# Altium schematic sheet AudioM_PowerAmp_v1.0.SchDoc
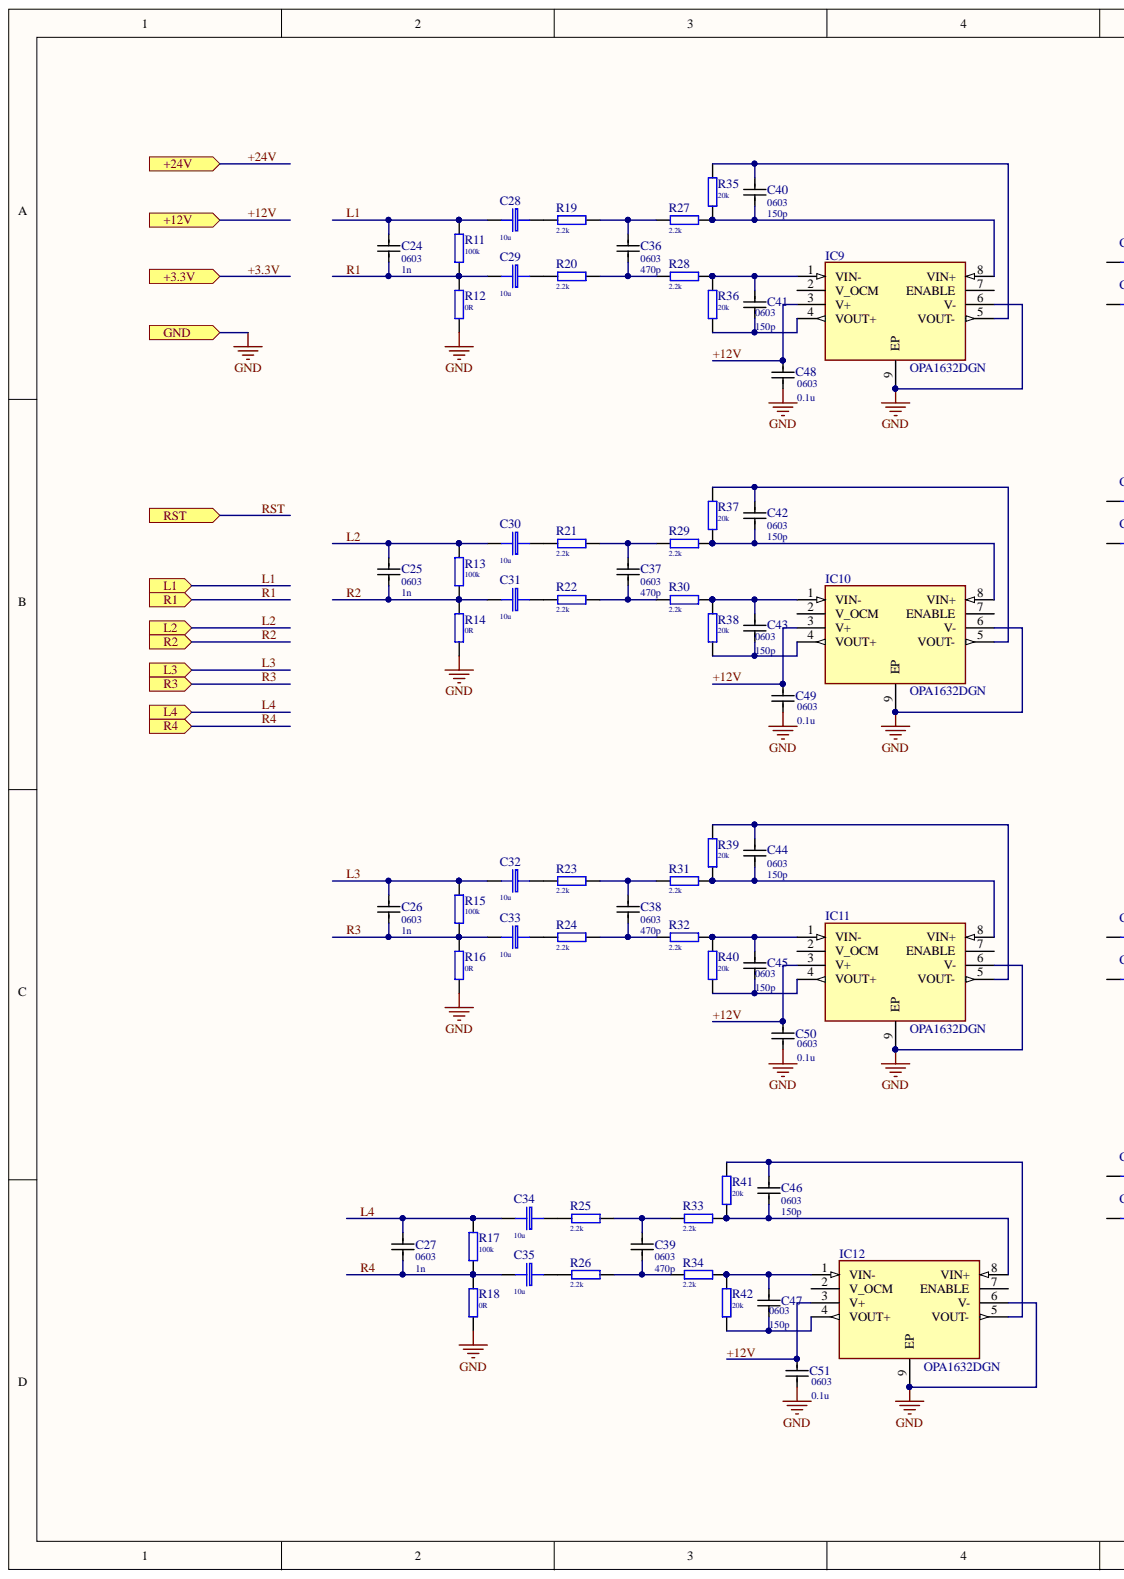
[diagram p0: AudioM_PowerAmp_v1.0.SchDoc, left]
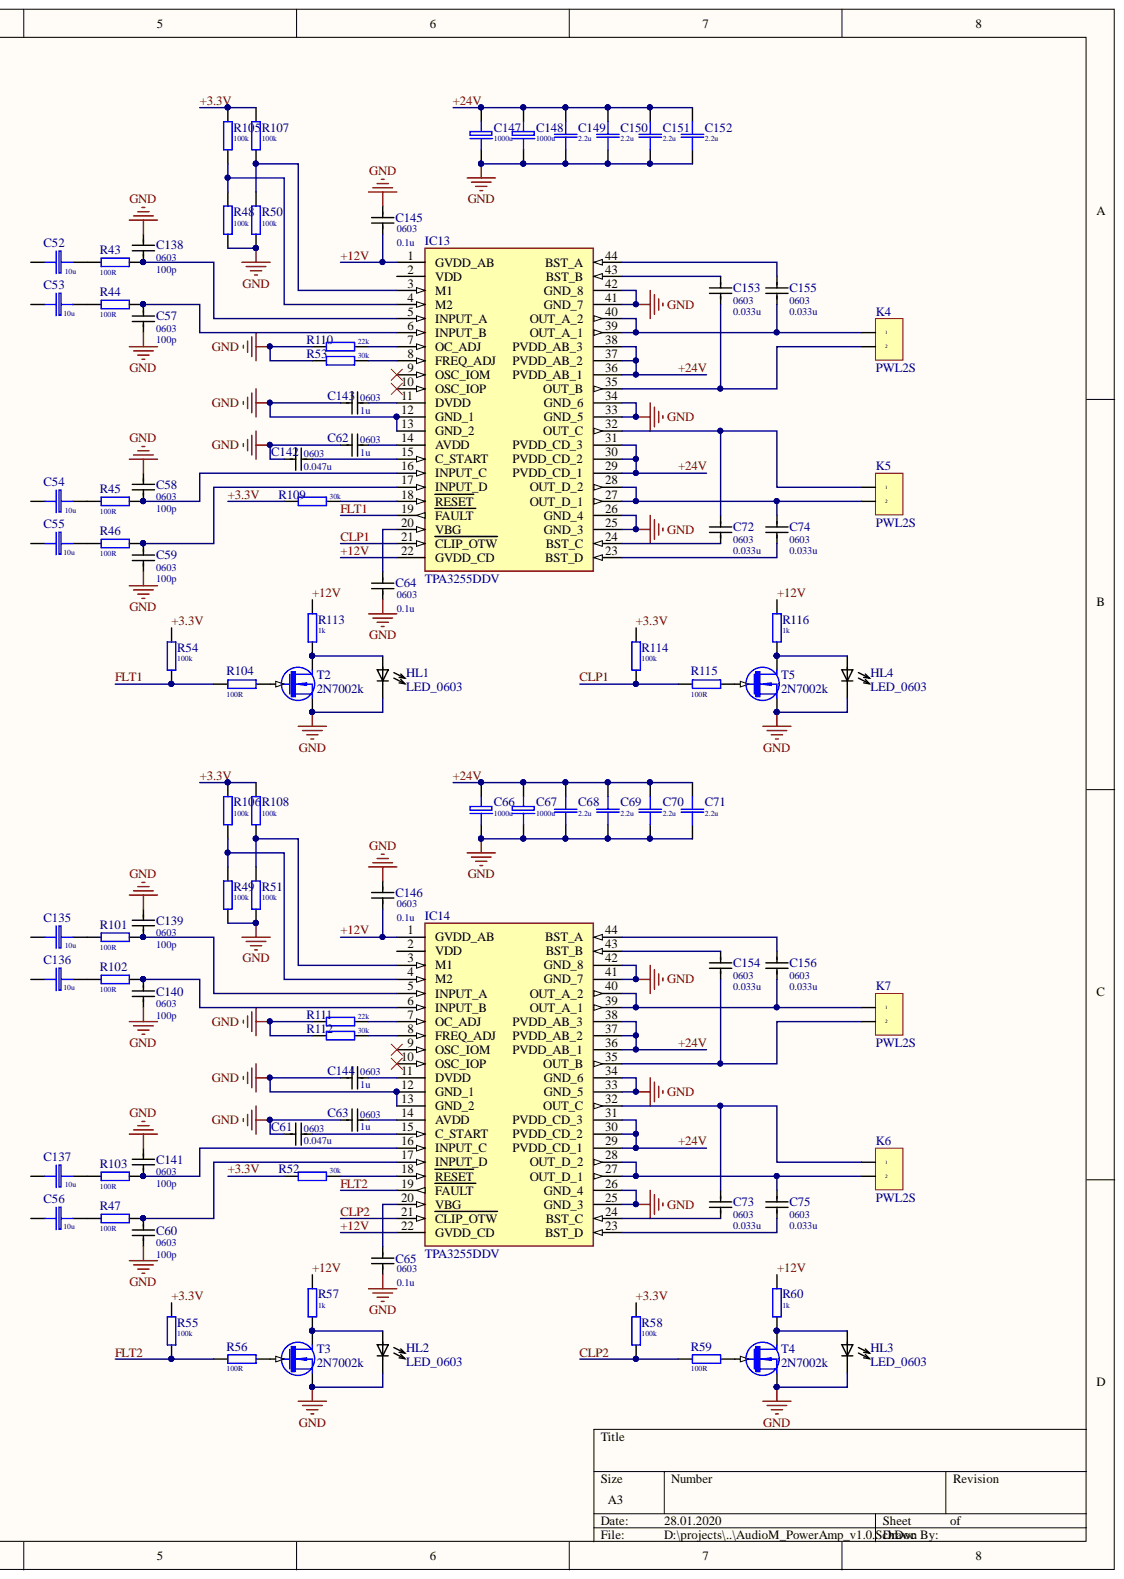
[diagram p1: AudioM_PowerAmp_v1.0.SchDoc, right]

## Parts
C24  0603  value 1n  [CAPC1608N]
C25  0603  value 1n  [CAPC1608N]
C26  0603  value 1n  [CAPC1608N]
C27  0603  value 1n  [CAPC1608N]
C28  10u  [EL Case B (3528-21)]
C29  10u  [EL Case B (3528-21)]
C30  10u  [EL Case B (3528-21)]
C31  10u  [EL Case B (3528-21)]
C32  10u  [EL Case B (3528-21)]
C33  10u  [EL Case B (3528-21)]
C34  10u  [EL Case B (3528-21)]
C35  10u  [EL Case B (3528-21)]
C36  0603  value 470p  [CAPC1608N]
C37  0603  value 470p  [CAPC1608N]
C38  0603  value 470p  [CAPC1608N]
C39  0603  value 470p  [CAPC1608N]
C40  0603  value 150p  [CAPC1608N]
C41  0603  value 150p  [CAPC1608N]
C42  0603  value 150p  [CAPC1608N]
C43  0603  value 150p  [CAPC1608N]
C44  0603  value 150p  [CAPC1608N]
C45  0603  value 150p  [CAPC1608N]
C46  0603  value 150p  [CAPC1608N]
C47  0603  value 150p  [CAPC1608N]
C48  0603  value 0.1u  [CAPC1608N]
C49  0603  value 0.1u  [CAPC1608N]
C50  0603  value 0.1u  [CAPC1608N]
C51  0603  value 0.1u  [CAPC1608N]
C52  10u  [EL Case B (3528-21)]
C53  10u  [EL Case B (3528-21)]
C54  10u  [EL Case B (3528-21)]
C55  10u  [EL Case B (3528-21)]
C56  10u  [EL Case B (3528-21)]
C57  0603  value 100p  [CAPC1608N]
C58  0603  value 100p  [CAPC1608N]
C59  0603  value 100p  [CAPC1608N]
C60  0603  value 100p  [CAPC1608N]
C61  0603  value 0.047u  [CAPC1608N]
C62  0603  value 1u  [CAPC1608N]
C63  0603  value 1u  [CAPC1608N]
C64  0603  value 0.1u  [CAPC1608N]
C65  0603  value 0.1u  [CAPC1608N]
C66  1000u  [El-d10-h16-F5.08]
C67  1000u  [El-d10-h16-F5.08]
C68  2.2u  [C0805(2013)]
C69  2.2u  [C0805(2013)]
C70  2.2u  [C0805(2013)]
C71  2.2u  [C0805(2013)]
C72  0603  value 0.033u  [CAPC1608N]
C73  0603  value 0.033u  [CAPC1608N]
C74  0603  value 0.033u  [CAPC1608N]
C75  0603  value 0.033u  [CAPC1608N]
C135  10u  [EL Case B (3528-21)]
C136  10u  [EL Case B (3528-21)]
C137  10u  [EL Case B (3528-21)]
C138  0603  value 100p  [CAPC1608N]
C139  0603  value 100p  [CAPC1608N]
C140  0603  value 100p  [CAPC1608N]
C141  0603  value 100p  [CAPC1608N]
C142  0603  value 0.047u  [CAPC1608N]
C143  0603  value 1u  [CAPC1608N]
C144  0603  value 1u  [CAPC1608N]
C145  0603  value 0.1u  [CAPC1608N]
C146  0603  value 0.1u  [CAPC1608N]
C147  1000u  [El-d10-h16-F5.08]
C148  1000u  [El-d10-h16-F5.08]
C149  2.2u  [C0805(2013)]
C150  2.2u  [C0805(2013)]
C151  2.2u  [C0805(2013)]
C152  2.2u  [C0805(2013)]
C153  0603  value 0.033u  [CAPC1608N]
C154  0603  value 0.033u  [CAPC1608N]
C155  0603  value 0.033u  [CAPC1608N]
C156  0603  value 0.033u  [CAPC1608N]
HL1  LED_0603  [DIOC1608X08N]
HL2  LED_0603  [DIOC1608X08N]
HL3  LED_0603  [DIOC1608X08N]
HL4  LED_0603  [DIOC1608X08N]
IC9  OPA1632DGN  [SOP65P490X110-9N]
IC10  OPA1632DGN  [SOP65P490X110-9N]
IC11  OPA1632DGN  [SOP65P490X110-9N]
IC12  OPA1632DGN  [SOP65P490X110-9N]
IC13  TPA3255DDV  [SOP64P810X120-44N]
IC14  TPA3255DDV  [SOP64P810X120-44N]
K4  PWL2S  [PWL2S]
K5  PWL2S  [PWL2S]
K6  PWL2S  [PWL2S]
K7  PWL2S  [PWL2S]
R11  100k  [R0603]
R12  0R  [R0603]
R13  100k  [R0603]
R14  0R  [R0603]
R15  100k  [R0603]
R16  0R  [R0603]
R17  100k  [R0603]
R18  0R  [R0603]
R19  2.2k  [R0603]
R20  2.2k  [R0603]
R21  2.2k  [R0603]
R22  2.2k  [R0603]
R23  2.2k  [R0603]
R24  2.2k  [R0603]
R25  2.2k  [R0603]
R26  2.2k  [R0603]
R27  2.2k  [R0603]
R28  2.2k  [R0603]
R29  2.2k  [R0603]
R30  2.2k  [R0603]
R31  2.2k  [R0603]
R32  2.2k  [R0603]
R33  2.2k  [R0603]
R34  2.2k  [R0603]
R35  20k  [R0603]
R36  20k  [R0603]
R37  20k  [R0603]
R38  20k  [R0603]
R39  20k  [R0603]
R40  20k  [R0603]
R41  20k  [R0603]
R42  20k  [R0603]
R43  100R  [R0603]
R44  100R  [R0603]
R45  100R  [R0603]
R46  100R  [R0603]
R47  100R  [R0603]
R48  100k  [R0603]
R49  100k  [R0603]
R50  100k  [R0603]
R51  100k  [R0603]
R52  30k  [R0603]
R53  30k  [R0603]
R54  100k  [R0603]
R55  100k  [R0603]
R56  100R  [R0603]
R57  1k  [R0603]
R58  100k  [R0603]
R59  100R  [R0603]
R60  1k  [R0603]
R101  100R  [R0603]
R102  100R  [R0603]
R103  100R  [R0603]
R104  100R  [R0603]
R105  100k  [R0603]
R106  100k  [R0603]
R107  100k  [R0603]
R108  100k  [R0603]
R109  30k  [R0603]
R110  22k  [R0603]
R111  22k  [R0603]
R112  30k  [R0603]
R113  1k  [R0603]
R114  100k  [R0603]
R115  100R  [R0603]
R116  1k  [R0603]
T2  2N7002k  [SOT23]
T3  2N7002k  [SOT23]
T4  2N7002k  [SOT23]
T5  2N7002k  [SOT23]

## Ports
+3.3V, +12V, +24V, GND, L1, L2, L3, L4, R1, R2, R3, R4, RST

## Nets
+3.3V: R52.2 R54.1 R55.1 R58.1 R105.1 R106.1 R107.1 R108.1 R109.2 R114.1 ; port +3.3V
+12V: C48.2 C49.2 C50.2 C51.2 C145.1 C146.1 IC9.3(V+) IC10.3(V+) IC11.3(V+) IC12.3(V+) IC13.1(GVDD_AB) IC13.22(GVDD_CD) IC14.1(GVDD_AB) IC14.22(GVDD_CD) R57.1 R60.1 R113.1 R116.1 ; port +12V
+24V: C66.1 C67.1 C68.1 C69.1 C70.1 C71.1 C147.1 C148.1 C149.1 C150.1 C151.1 C152.1 IC13.29(PVDD_CD_1) IC13.30(PVDD_CD_2) IC13.31(PVDD_CD_3) IC13.36(PVDD_AB_1) IC13.37(PVDD_AB_2) IC13.38(PVDD_AB_3) IC14.29(PVDD_CD_1) IC14.30(PVDD_CD_2) IC14.31(PVDD_CD_3) IC14.36(PVDD_AB_1) IC14.37(PVDD_AB_2) IC14.38(PVDD_AB_3) ; port +24V
CLP1: IC13.21(CLIP_OTW) R114.2 R115.2
CLP2: IC14.21(CLIP_OTW) R58.2 R59.2
FLT1: IC13.19(FAULT) R54.2 R104.2
FLT2: IC14.19(FAULT) R55.2 R56.2
GND: C48.1 C49.1 C50.1 C51.1 C57.1 C58.1 C59.1 C60.1 C61.2 C62.2 C63.2 C64.1 C65.1 C66.2 C67.2 C68.2 C69.2 C70.2 C71.2 C138.1 C139.1 C140.1 C141.1 C142.2 C143.2 C144.2 C145.2 C146.2 C147.2 C148.2 C149.2 C150.2 C151.2 C152.2 HL1.2 HL2.2 HL3.2 HL4.2 IC9.6(V-) IC9.9(EP) IC10.6(V-) IC10.9(EP) IC11.6(V-) IC11.9(EP) IC12.6(V-) IC12.9(EP) IC13.12(GND_1) IC13.13(GND_2) IC13.25(GND_3) IC13.26(GND_4) IC13.33(GND_5) IC13.34(GND_6) IC13.41(GND_7) IC13.42(GND_8) IC14.12(GND_1) IC14.13(GND_2) IC14.25(GND_3) IC14.26(GND_4) IC14.33(GND_5) IC14.34(GND_6) IC14.41(GND_7) IC14.42(GND_8) R12.2 R14.2 R16.2 R18.2 R48.2 R49.2 R50.2 R51.2 R53.2 R110.2 R111.2 R112.2 T2.2 T3.2 T4.2 T5.2 ; port GND
L1: C24.2 C28.2 R11.1 ; port L1
L2: C25.2 C30.2 R13.1 ; port L2
L3: C26.2 C32.2 R15.1 ; port L3
L4: C27.2 C34.2 R17.1 ; port L4
R1: C24.1 C29.2 R11.2 R12.1 ; port R1
R2: C25.1 C31.2 R13.2 R14.1 ; port R2
R3: C26.1 C33.2 R15.2 R16.1 ; port R3
R4: C27.1 C35.2 R17.2 R18.1 ; port R4
NetC28_1: C28.1 R19.2
NetC29_1: C29.1 R20.2
NetC30_1: C30.1 R21.2
NetC31_1: C31.1 R22.2
NetC32_1: C32.1 R23.2
NetC33_1: C33.1 R24.2
NetC34_1: C34.1 R25.2
NetC35_1: C35.1 R26.2
NetC36_1: C36.1 R20.1 R28.2
NetC36_2: C36.2 R19.1 R27.2
NetC37_1: C37.1 R22.1 R30.2
NetC37_2: C37.2 R21.1 R29.2
NetC38_1: C38.1 R24.1 R32.2
NetC38_2: C38.2 R23.1 R31.2
NetC39_1: C39.1 R26.1 R34.2
NetC39_2: C39.2 R25.1 R33.2
NetC40_1: C40.1 IC9.8(VIN+) R27.1 R35.2
NetC40_2: C40.2 IC9.5(VOUT-) R35.1
NetC41_1: C41.1 IC9.4(VOUT+) R36.2
NetC41_2: C41.2 IC9.1(VIN-) R28.1 R36.1
NetC42_1: C42.1 IC10.8(VIN+) R29.1 R37.2
NetC42_2: C42.2 IC10.5(VOUT-) R37.1
NetC43_1: C43.1 IC10.4(VOUT+) R38.2
NetC43_2: C43.2 IC10.1(VIN-) R30.1 R38.1
NetC44_1: C44.1 IC11.8(VIN+) R31.1 R39.2
NetC44_2: C44.2 IC11.5(VOUT-) R39.1
NetC45_1: C45.1 IC11.4(VOUT+) R40.2
NetC45_2: C45.2 IC11.1(VIN-) R32.1 R40.1
NetC46_1: C46.1 IC12.8(VIN+) R33.1 R41.2
NetC46_2: C46.2 IC12.5(VOUT-) R41.1
NetC47_1: C47.1 IC12.4(VOUT+) R42.2
NetC47_2: C47.2 IC12.1(VIN-) R34.1 R42.1
NetC52_1: C52.1 R43.2
NetC53_1: C53.1 R44.2
NetC54_1: C54.1 R45.2
NetC55_1: C55.1 R46.2
NetC56_1: C56.1 R47.2
NetC57_2: C57.2 IC13.6(INPUT_B) R44.1
NetC58_2: C58.2 IC13.16(INPUT_C) R45.1
NetC59_2: C59.2 IC13.17(INPUT_D) R46.1
NetC60_2: C60.2 IC14.17(INPUT_D) R47.1
NetC61_1: C61.1 IC14.15(C_START)
NetC62_1: C62.1 IC13.14(AVDD)
NetC63_1: C63.1 IC14.14(AVDD)
NetC64_2: C64.2 IC13.20(VBG)
NetC65_2: C65.2 IC14.20(VBG)
NetC72_1: C72.1 IC13.24(BST_C)
NetC72_2: C72.2 IC13.32(OUT_C) K5.1
NetC73_1: C73.1 IC14.24(BST_C)
NetC73_2: C73.2 IC14.32(OUT_C) K6.1
NetC74_1: C74.1 IC13.23(BST_D)
NetC74_2: C74.2 IC13.27(OUT_D_1) IC13.28(OUT_D_2) K5.2
NetC75_1: C75.1 IC14.23(BST_D)
NetC75_2: C75.2 IC14.27(OUT_D_1) IC14.28(OUT_D_2) K6.2
NetC135_1: C135.1 R101.2
NetC136_1: C136.1 R102.2
NetC137_1: C137.1 R103.2
NetC138_2: C138.2 IC13.5(INPUT_A) R43.1
NetC139_2: C139.2 IC14.5(INPUT_A) R101.1
NetC140_2: C140.2 IC14.6(INPUT_B) R102.1
NetC141_2: C141.2 IC14.16(INPUT_C) R103.1
NetC142_1: C142.1 IC13.15(C_START)
NetC143_1: C143.1 IC13.11(DVDD)
NetC144_1: C144.1 IC14.11(DVDD)
NetC153_1: C153.1 IC13.35(OUT_B) K4.2
NetC153_2: C153.2 IC13.43(BST_B)
NetC154_1: C154.1 IC14.35(OUT_B) K7.2
NetC154_2: C154.2 IC14.43(BST_B)
NetC155_1: C155.1 IC13.39(OUT_A_1) IC13.40(OUT_A_2) K4.1
NetC155_2: C155.2 IC13.44(BST_A)
NetC156_1: C156.1 IC14.39(OUT_A_1) IC14.40(OUT_A_2) K7.1
NetC156_2: C156.2 IC14.44(BST_A)
NetHL1_1: HL1.1 R113.2 T2.3
NetHL2_1: HL2.1 R57.2 T3.3
NetHL3_1: HL3.1 R60.2 T4.3
NetHL4_1: HL4.1 R116.2 T5.3
NetIC13_3: IC13.3(M1) R50.1 R107.2
NetIC13_4: IC13.4(M2) R48.1 R105.2
NetIC13_7: IC13.7(OC_ADJ) R110.1
NetIC13_8: IC13.8(FREQ_ADJ) R53.1
NetIC13_18: IC13.18(RESET) R109.1
NetIC14_3: IC14.3(M1) R51.1 R108.2
NetIC14_4: IC14.4(M2) R49.1 R106.2
NetIC14_7: IC14.7(OC_ADJ) R111.1
NetIC14_8: IC14.8(FREQ_ADJ) R112.1
NetIC14_18: IC14.18(RESET) R52.1
NetR56_1: R56.1 T3.1
NetR59_1: R59.1 T4.1
NetR104_1: R104.1 T2.1
NetR115_1: R115.1 T5.1
RST: ; port RST

Not connected: C52 2; C53 2; C54 2; C55 2; C56 2; C135 2; C136 2; C137 2; IC9 2(V_OCM) 7(ENABLE); IC10 2(V_OCM) 7(ENABLE); IC11 2(V_OCM) 7(ENABLE); IC12 2(V_OCM) 7(ENABLE); IC13 2(VDD) 9(OSC_IOM) 10(OSC_IOP); IC14 2(VDD) 9(OSC_IOM) 10(OSC_IOP)

## Library data of the parts
C24-C27 C36-C51 C57-C65 C72-C75 C138-C144 C153-C156 = C0603: Component Kind: Standard; Library Name: PPK_v1.0.SCHLIB
C28-C35 C52-C56 C135-C137 = EL 10u/20V Tant.: Case B (3528-21); Component Kind: Standard; Library Name: SMD-RCL.IntLib; Price: 0.22; Signal Integrity: Cap; Simulation: Capacitor; Value: 10u
C66 C67 C147 C148 = 1000u-16V: 16V; Component Kind: Standard; Library Name: Cap-El.SchLib; Price: 0.18; Signal Integrity: C; Simulation: C; Value: 1000u
C68-C71 C149-C152 = C-0805   16V 2.2u: SMD-0805 16V Y5V +80/-20%; Component Kind: Standard; Library Name: SMD-RCL.IntLib; Price: 0.054; Signal Integrity: Cap; Simulation: Capacitor; Value: 2.2u
C145 C146 = C0603: Value: 0.1u; Component Kind: Standard; Library Name: PPK_v1.0.SCHLIB
IC9-IC12 = OPA1632DGN: Integrated Circuit; Manufacturer_Name: Texas Instruments; Component Kind: Standard; Datasheet Link: http://www.ti.com/lit/ds/symlink/opa1632.pdf; Height: 1.1mm; Manufacturer_Part_Number: OPA1632DGN; Mouser Part Number: 595-OPA1632DGN; Mouser Price/Stock: https://www.mouser.com/Search/Refine.aspx?Keyword=595-OPA1632DGN; RS Part Number: 5170227P; RS Price/Stock: http://uk.rs-online.com/web/p/products/5170227P
IC13 IC14 = TPA3255DDV: Hardware; Manufacturer_Name: Texas Instruments; Component Kind: Standard; Datasheet Link: http://TI/TPA3255; Height: 1.2mm; Manufacturer_Part_Number: TPA3255DDV; Mouser Part Number: 595-TPA3255DDV; Mouser Price/Stock: https://www.mouser.com/Search/Refine.aspx?Keyword=595-TPA3255DDV
K4-K7 = PWL2S: 3.96mm 7A/250V; Component Kind: Standard; Library Name: Connektor.IntLib
R11-R60 R101-R116 = R-0603: SMD 0603 0.0625W 5% 50V; Component Kind: Standard; Library Name: SMD-RCL.IntLib; Price: 0.017; Signal Integrity: Res; Simulation: Resistor
  R11 R13 R15 R17 R48-R51 R54 R55 R58 R105-R108 R114: Value: 100k
  R12 R14 R16 R18: Value: 0R
  R19-R34: Value: 2.2k
  R35-R42: Value: 20k
  R43-R47 R56 R59 R101-R104 R115: Value: 100R
  R52 R53 R109 R112: Value: 30k
  R57 R60 R113 R116: Value: 1k
  R110 R111: Value: 22k
T2-T5 = 2N7002k: N-MOS 60V 7.5om 0.115mA; Component Kind: Standard; Library Name: MOS-FET.IntLib; price: 0.04; Simulation: 2N7002k
HL1-HL4 = LED_0603: Component Kind: Standard; Library Name: DNA_MM_v1.0.SCHLIB
